# Altium schematic sheet Power.SchDoc(Power)
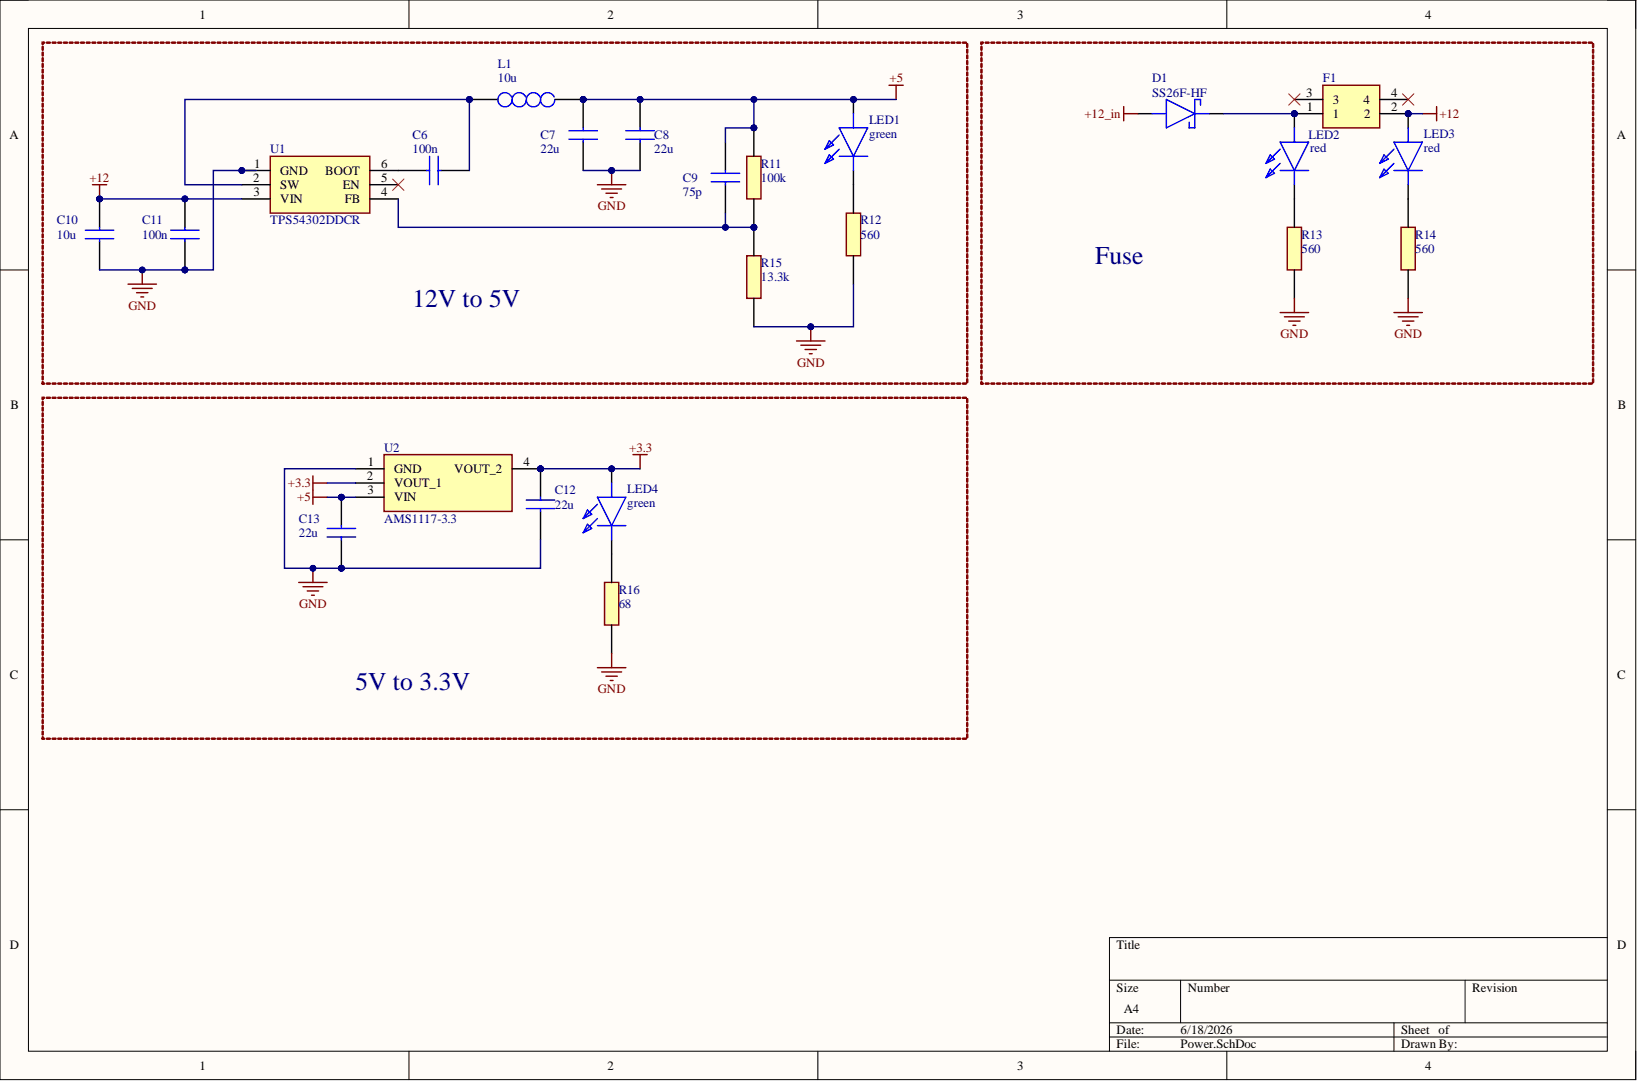
[diagram p0: Power.SchDoc]

## Parts
C6  100n
C7  22u
C8  22u
C9  75p
C10  10u
C11  100n
C12  22u
C13  22u
D1  SS26F-HF
F1  XF-508P-B-B  (value not drawn)
L1  10u
LED1  green
LED2  red
LED3  red
LED4  green
R11  100k
R12  560
R13  560
R14  560
R15  13.3k
R16  68
U1  TPS54302DDCR
U2  AMS1117-3.3

## Nets
+3.3: C12.2 LED4.2 U2.2(VOUT_1) U2.4(VOUT_2)
+5: C7.2 C8.2 C9.2 C13.2 L1.2 LED1.2 R11.2 U2.3(VIN)
+12: C10.2 C11.2 F1.2 LED3.2 U1.3(VIN)
+12_in: D1.2
GND: C7.1 C8.1 C10.1 C11.1 C12.1 C13.1 R12.1 R13.1 R14.1 R15.1 R16.2 U1.1(GND) U2.1(GND)
NetC6_1: C6.1 U1.6(BOOT)
NetC6_2: C6.2 L1.1 U1.2(SW)
NetC9_1: C9.1 R11.1 R15.2 U1.4(FB)
NetD1_1: D1.1 F1.1 LED2.2
NetLED1_1: LED1.1 R12.2
NetLED2_1: LED2.1 R13.2
NetLED3_1: LED3.1 R14.2
NetLED4_1: LED4.1 R16.1

Not connected: F1 3 4; U1 5(EN)

## Library data of the parts
C6 C11 = CL21B104KBCNNNC: Capacitor; Manufacturer_Name: SAMSUNG; Arrow Part Number: CL21B104KBCNNNC; Arrow Price/Stock: https://www.arrow.com/en/products/cl21b104kbcnnnc/samsung-electro-mechanics?region=europe; Component Kind: Standard; Datasheet Link: https://datasheet.datasheetarchive.com/originals/distributors/Datasheets_SAMA/86a3eaf0882763ef32124d5901f9b833.pdf; Height: 0.95mm; Manufacturer_Part_Number: CL21B104KBCNNNC; Mouser Part Number: 187-CL21B104KBCNNNC; Mouser Price/Stock: https://www.mouser.co.uk/ProductDetail/Samsung-Electro-Mechanics/CL21B104KBCNNNC?qs=349EhDEZ59pEfpnm5yBEmQ%3D%3D
C7 C8 C12 C13 = CL21A226MAYNNNE: Capacitor; Manufacturer_Name: SAMSUNG; Arrow Part Number: CL21A226MAYNNNE; Arrow Price/Stock: https://www.arrow.com/en/products/cl21a226maynnne/samsung-electro-mechanics?utm_currency=USD&region=europe; Component Kind: Standard; Datasheet Link: http://product.samsungsem.com/mlcc/CL21A226MAYNNN.do; Height: 1.45mm; Manufacturer_Part_Number: CL21A226MAYNNNE; Mouser Part Number: 187-CL21A226MAYNNNE; Mouser Price/Stock: https://www.mouser.co.uk/ProductDetail/Samsung-Electro-Mechanics/CL21A226MAYNNNE?qs=xZ%2FP%252Ba9zWqY%2FVvCfXjMhqg%3D%3D
C9 = GQM2195C2E750JB12D: Capacitor; Manufacturer_Name: Murata Electronics; Component Kind: Standard; Datasheet Link: https://search.murata.co.jp/Ceramy/image/img/A01X/G101/ENG/GQM2195C2E750JB12-01A.pdf; Height: 1mm; Manufacturer_Part_Number: GQM2195C2E750JB12D
C10 = CL21A106KAYNNNE: Capacitor; Manufacturer_Name: SAMSUNG; Arrow Part Number: CL21A106KAYNNNE; Arrow Price/Stock: https://www.arrow.com/en/products/cl21a106kaynnne/samsung-electro-mechanics?utm_currency=USD&region=nac; Component Kind: Standard; Datasheet Link: https://datasheet.datasheetarchive.com/originals/distributors/Datasheets_SAMA/6a45835c9b7400e9b49c55c38a8ff4a2.pdf; Height: 1.45mm; Library Name: SamacSys.SchLib; Manufacturer_Part_Number: CL21A106KAYNNNE; Mouser Part Number: 187-CL21A106KAYNNNE; Mouser Price/Stock: https://www.mouser.co.uk/ProductDetail/Samsung-Electro-Mechanics/CL21A106KAYNNNE?qs=yOVawPpwOwmTXAd4dJtNjA%3D%3D
D1 = SS26F-HF: Schottky Diode; Manufacturer_Name: Comchip Technology; Component Kind: Standard; Datasheet Link: https://www.mouser.in/datasheet/2/80/20190806173210-1729198.pdf; Height: 1.2mm; Manufacturer_Part_Number: SS26F-HF
F1 = XF-508P-B-B: Hardware; Manufacturer_Name: XFCN; Component Kind: Standard; Datasheet Link: https://www.xfconn.com/uploads/soft/20240323/4-24032311150D91.pdf; Height: 7.37mm; Manufacturer_Part_Number: XF-508P-B-B
L1 = LQM21FN100M80L: Inductor; Manufacturer_Name: Murata Electronics; Arrow Part Number: LQM21FN100M80L; Arrow Price/Stock: https://www.arrow.com/en/products/lqm21fn100m80l/murata-manufacturing?region=europe; Component Kind: Standard; Datasheet Link: https://search.murata.co.jp/Ceramy/image/img/P02/JELF243B-0017.pdf; Height: 1.45mm; Manufacturer_Part_Number: LQM21FN100M80L; Mouser Part Number: 81-LQM21FN100M80L; Mouser Price/Stock: https://www.mouser.co.uk/ProductDetail/Murata-Electronics/LQM21FN100M80L?qs=%2F%252BYoplLUB5jHVlRNWlo0OQ%3D%3D
LED1 LED4 = LTST-C170KGKT: LED; Manufacturer_Name: Lite-On; Arrow Part Number: LTST-C170KGKT; Arrow Price/Stock: https://www.arrow.com/en/products/ltst-c170kgkt/lite-on-technology?utm_currency=USD&region=europe; Component Kind: Standard; Datasheet Link: http://uk.rs-online.com/web/p/products/6920907/?grossPrice=Y&cm_mmc=UK-PLA-DS3A-_-google-_-PLA_UK_EN_Displays_And_Optoelectronics-_-LEDs_And_LED_Accessories-_-PRODUCT+GROUP&matchtype=&gclid=Cj0KCQjw8vnMBRDgARIsACm_BhL5snkjsAk6gK-vmanqdq02kRfWctzcy3t-1Yoin; Height: 1.1mm; Manufacturer_Part_Number: LTST-C170KGKT; Mouser Part Number: 859-LTST-C170KGKT; Mouser Price/Stock: https://www.mouser.co.uk/ProductDetail/Lite-On/LTST-C170KGKT?qs=o1ACzGp%252Bek3F8d3sLmVFGg%3D%3D
LED2 LED3 = NCD0805R1: LED; Manufacturer_Name: Foshan NationStar Optoelectronics; Component Kind: Standard; Datasheet Link: https://datasheet.lcsc.com/lcsc/2008201032_Foshan-NationStar-Optoelectronics-NCD0805R1_C84256.pdf; Height: 1.2mm; Manufacturer_Part_Number: NCD0805R1
R11 = RC0805FR-07100KL: Resistor; Manufacturer_Name: KEMET; Arrow Part Number: RC0805FR-07100KL; Arrow Price/Stock: https://www.arrow.com/en/products/rc0805fr-07100kl/yageo?region=nac; Component Kind: Standard; Datasheet Link: http://www.yageo.com/documents/recent/PYu-RC_Group_51_RoHS_L_10.pdf; Height: 0.6mm; Manufacturer_Part_Number: RC0805FR-07100KL; Mouser Part Number: 603-RC0805FR-07100KL; Mouser Price/Stock: https://www.mouser.co.uk/ProductDetail/YAGEO/RC0805FR-07100KL?qs=8Y8p%252BasKcI7rEWn6FcVdAA%3D%3D
R12-R14 = 0805W8F5600T5E: Resistor; Manufacturer_Name: UNI-ROYAL(Uniroyal Elec); Component Kind: Standard; Datasheet Link: https://datasheet.lcsc.com/szlcsc/Uniroyal-Elec-0805W8F5600T5E_C28636.pdf; Height: 0.65mm; Manufacturer_Part_Number: 0805W8F5600T5E
R15 = CRCW080513K3FKEA: Resistor; Manufacturer_Name: Vishay; Arrow Part Number: CRCW080513K3FKEA; Arrow Price/Stock: https://www.arrow.com/en/products/crcw080513k3fkea/vishay?region=nac; Component Kind: Standard; Datasheet Link: http://www.vishay.com/docs/20035/dcrcwe3.pdf; Height: 0.5mm; Manufacturer_Part_Number: CRCW080513K3FKEA; Mouser Part Number: 71-CRCW0805-13.3K-E3; Mouser Price/Stock: https://www.mouser.co.uk/ProductDetail/Vishay-Dale/CRCW080513K3FKEA?qs=0y7Riw94L4iJnrouDVBgwQ%3D%3D
R16 = RC0805FR-0768RL: Resistor; Manufacturer_Name: KEMET; Component Kind: Standard; Datasheet Link: http://www.yageo.com/documents/recent/PYu-RC_Group_51_RoHS_L_10.pdf; Height: 0.6mm; Manufacturer_Part_Number: RC0805FR-0768RL; Mouser Part Number: 603-RC0805FR-0768RL; Mouser Price/Stock: https://www.mouser.com/Search/Refine.aspx?Keyword=603-RC0805FR-0768RL
U1 = TPS54302DDCR: Integrated Circuit; Manufacturer_Name: Texas Instruments; Arrow Part Number: TPS54302DDCR; Arrow Price/Stock: https://www.arrow.com/en/products/tps54302ddcr/texas-instruments?utm_currency=USD&region=nac; Component Kind: Standard; Datasheet Link: http://www.ti.com/general/docs/suppproductinfo.tsp?distId=10&gotoUrl=http%3A%2F%2Fwww.ti.com%2Flit%2Fgpn%2Ftps54302; Height: 1.1mm; Manufacturer_Part_Number: TPS54302DDCR; Mouser Part Number: 595-TPS54302DDCR; Mouser Price/Stock: https://www.mouser.co.uk/ProductDetail/Texas-Instruments/TPS54302DDCR?qs=zEmsApcVOkUXM7UP0GSO2g%3D%3D
U2 = AMS1117-3.3: Integrated Circuit; Manufacturer_Name: Advanced Monolithic Systems; Component Kind: Standard; Datasheet Link: http://www.advanced-monolithic.com/pdf/ds1117.pdf; Height: 1.8mm; Manufacturer_Part_Number: AMS1117-3.3
